# Altium schematic sheet Connector.SchDoc(Connector)
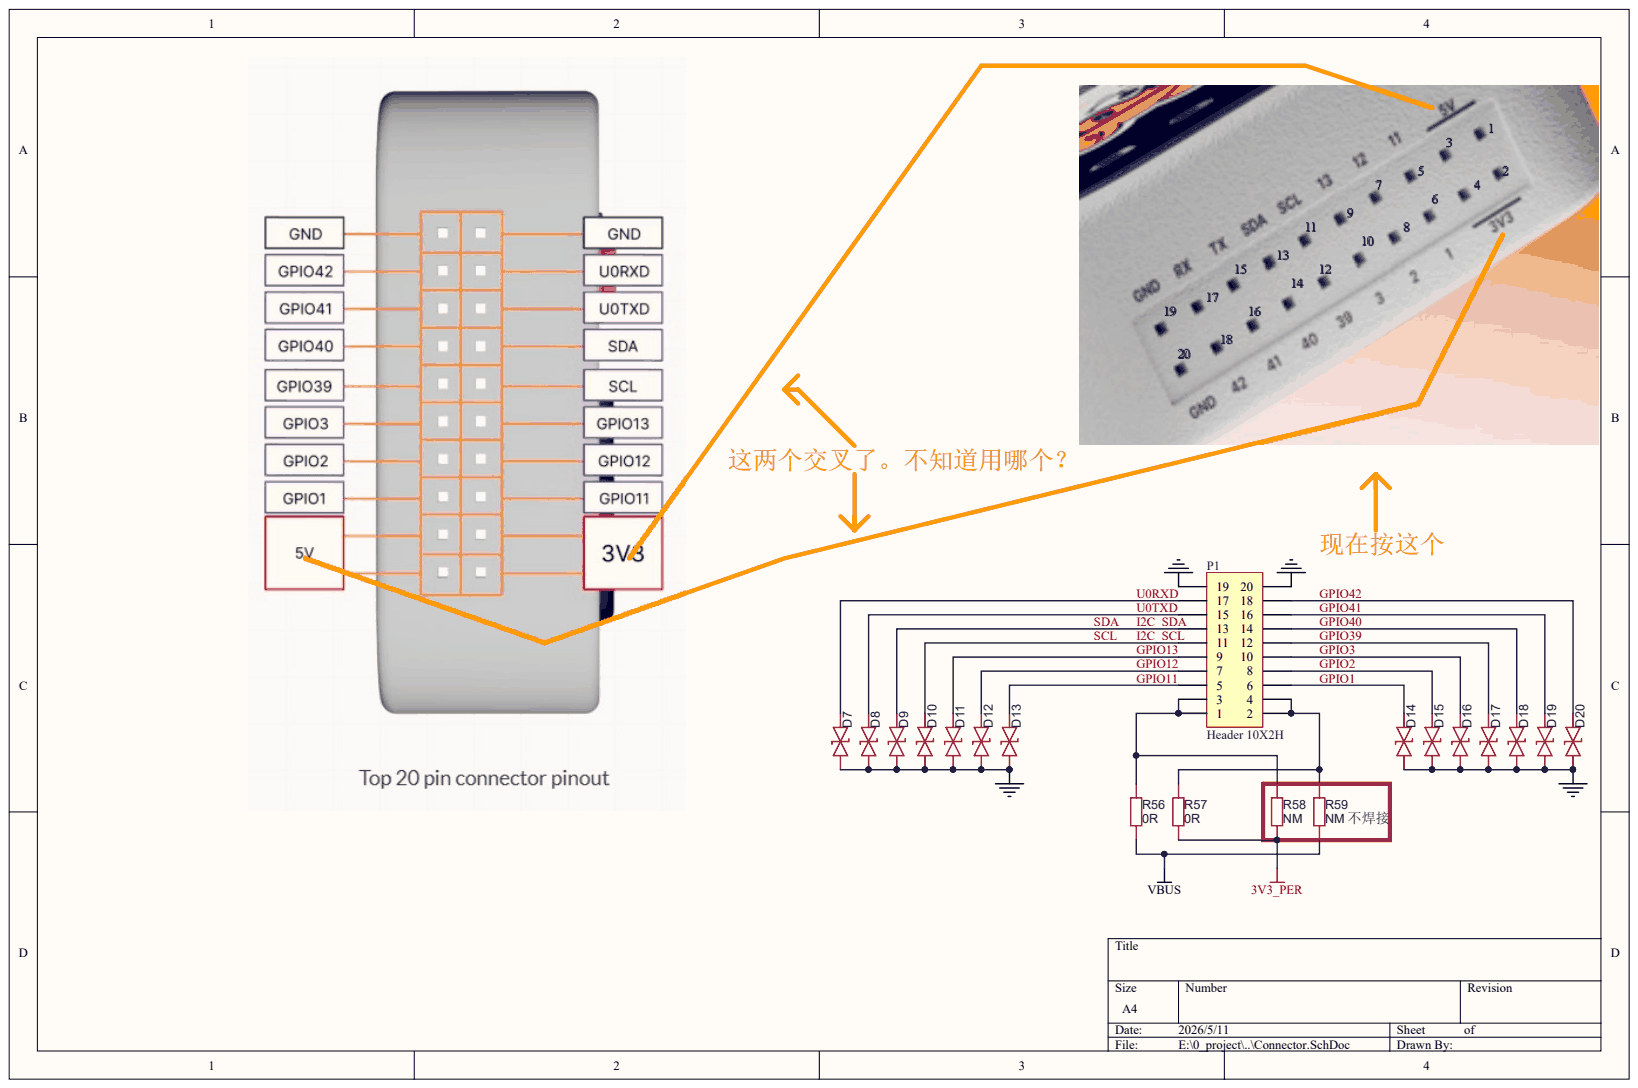
[diagram p0: Connector.SchDoc]

## Parts
D7  LESD8D3.3CAT5G  (value not drawn)
D8  LESD8D3.3CAT5G  (value not drawn)
D9  LESD8D3.3CAT5G  (value not drawn)
D10  LESD8D3.3CAT5G  (value not drawn)
D11  LESD8D3.3CAT5G  (value not drawn)
D12  LESD8D3.3CAT5G  (value not drawn)
D13  LESD8D3.3CAT5G  (value not drawn)
D14  LESD8D3.3CAT5G  (value not drawn)
D15  LESD8D3.3CAT5G  (value not drawn)
D16  LESD8D3.3CAT5G  (value not drawn)
D17  LESD8D3.3CAT5G  (value not drawn)
D18  LESD8D3.3CAT5G  (value not drawn)
D19  LESD8D3.3CAT5G  (value not drawn)
D20  LESD8D3.3CAT5G  (value not drawn)
P1  Header 10X2H
R56  value 0R  (hidden comment: 10K)
R57  value 0R  (hidden comment: 10K)
R58  value NM  (hidden comment: 10K)
R59  value NM  (hidden comment: 10K)

## Nets
3V3_PER: R57.2 R58.2
GND: D7.1 D8.1 D9.1 D10.1 D11.1 D12.1 D13.1 D14.1 D15.1 D16.1 D17.1 D18.1 D19.1 D20.1 P1.19 P1.20
VBUS: R56.2 R59.2
GPIO1: D14.2 P1.6
GPIO2: D15.2 P1.8
GPIO3: D16.2 P1.10
GPIO11: D13.2 P1.5
GPIO12: D12.2 P1.7
GPIO13: D11.2 P1.9
GPIO39: D17.2 P1.12
GPIO40: D18.2 P1.14
GPIO41: D19.2 P1.16
GPIO42: D20.2 P1.18
I2C_SCL: D10.2 P1.11
I2C_SDA: D9.2 P1.13
U0RXD: D7.2 P1.17
U0TXD: D8.2 P1.15
NetP1_1: P1.1 P1.3 R56.1 R58.1
NetP1_2: P1.2 P1.4 R57.1 R59.1

## Library data of the parts
D7-D20 = (no symbol name): Device: LESD8D3.3CAT5G_C172409; AddInBom: yes; ModelId: 072dcf228994456dbe83693440270b5f; ModelName: WBFBP-03E_L1.0-W0.6-P0.64-BL; ModelTransform: 40.1574,23.622,0,0,0,0,0,0,0; Reverse Stand-Off Voltage (Vrwm): 3.3V; Maximum Clamping Voltage: 10V; Peak Pulse Current (Ipp)@10/1000us: 6A; Breakdown Voltage: 5V; Type: ESD; Symbol: LESD8D3.3CAT5G; SupplierPart: C172409; ManufacturerPart: LESD8D3.3CAT5G; Supplier Footprint: SOD-882; Datasheet: https://atta.szlcsc.com/upload/public/pdf/source/20180130/C172409_15172974211921323438.pdf; Supplier: LCSC; ConvertToPcb: yes; FootprintName: SOD-882_L1.0-W0.6-BI
R56-R59 = (no symbol name): Device: 0402WGJ0472TCE; AddInBom: yes; ModelId: 671aefced39d4c318694bba8bd8efd39; ModelName: R0402_L1.0-W0.5-H0.5; ModelTransform: 39.37,19.685,0,0,0,0,0,0.0005,0; Power(Watts): 62.5mW; Overload Voltage (Max): 50V; Symbol: 0402WGJ0472TCE; SupplierPart: C25940; ManufacturerPart: 0402WGJ0472TCE; Supplier Footprint: 0402; Datasheet: https://atta.szlcsc.com/upload/public/pdf/source/20200306/C422600_1E6D84923E4A46A82E41ADD87F860B5C.pdf; Supplier: LCSC; ConvertToPcb: yes; FootprintName: R0402
P1 = (no symbol name): LatestRevisionDate: 17-Jul-2002; LatestRevisionNote: Re-released for DXP Platform.; Publisher: Altium Limited
